# Altium schematic sheet LED_Readout.SchDoc
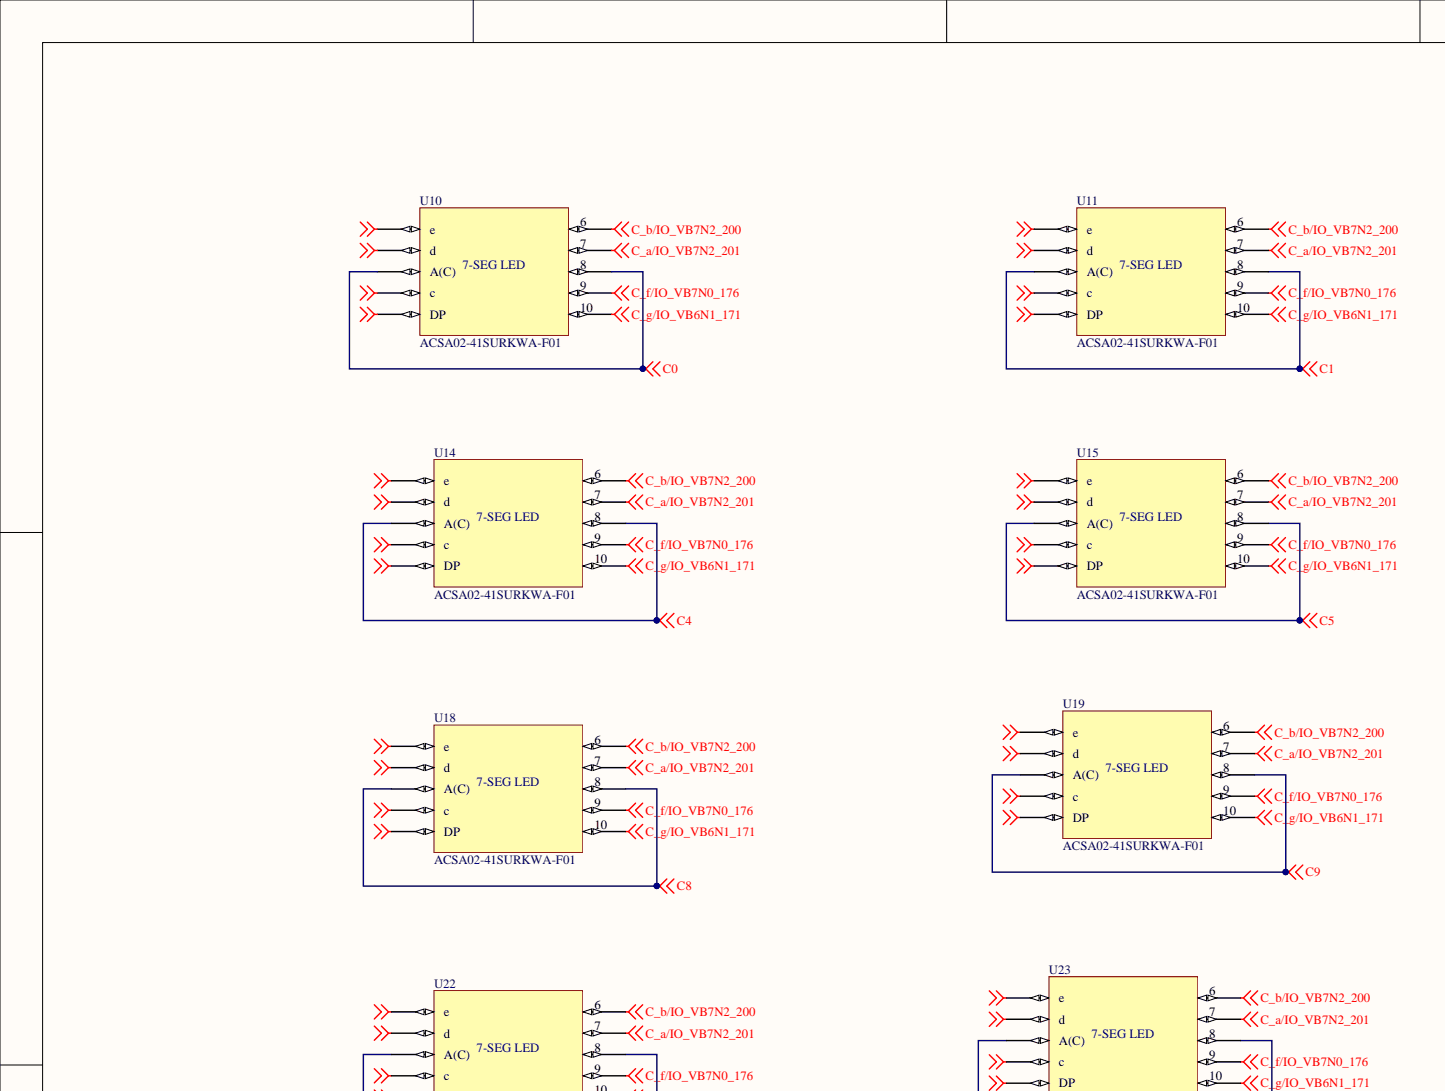
[diagram p0: LED_Readout.SchDoc, top left]
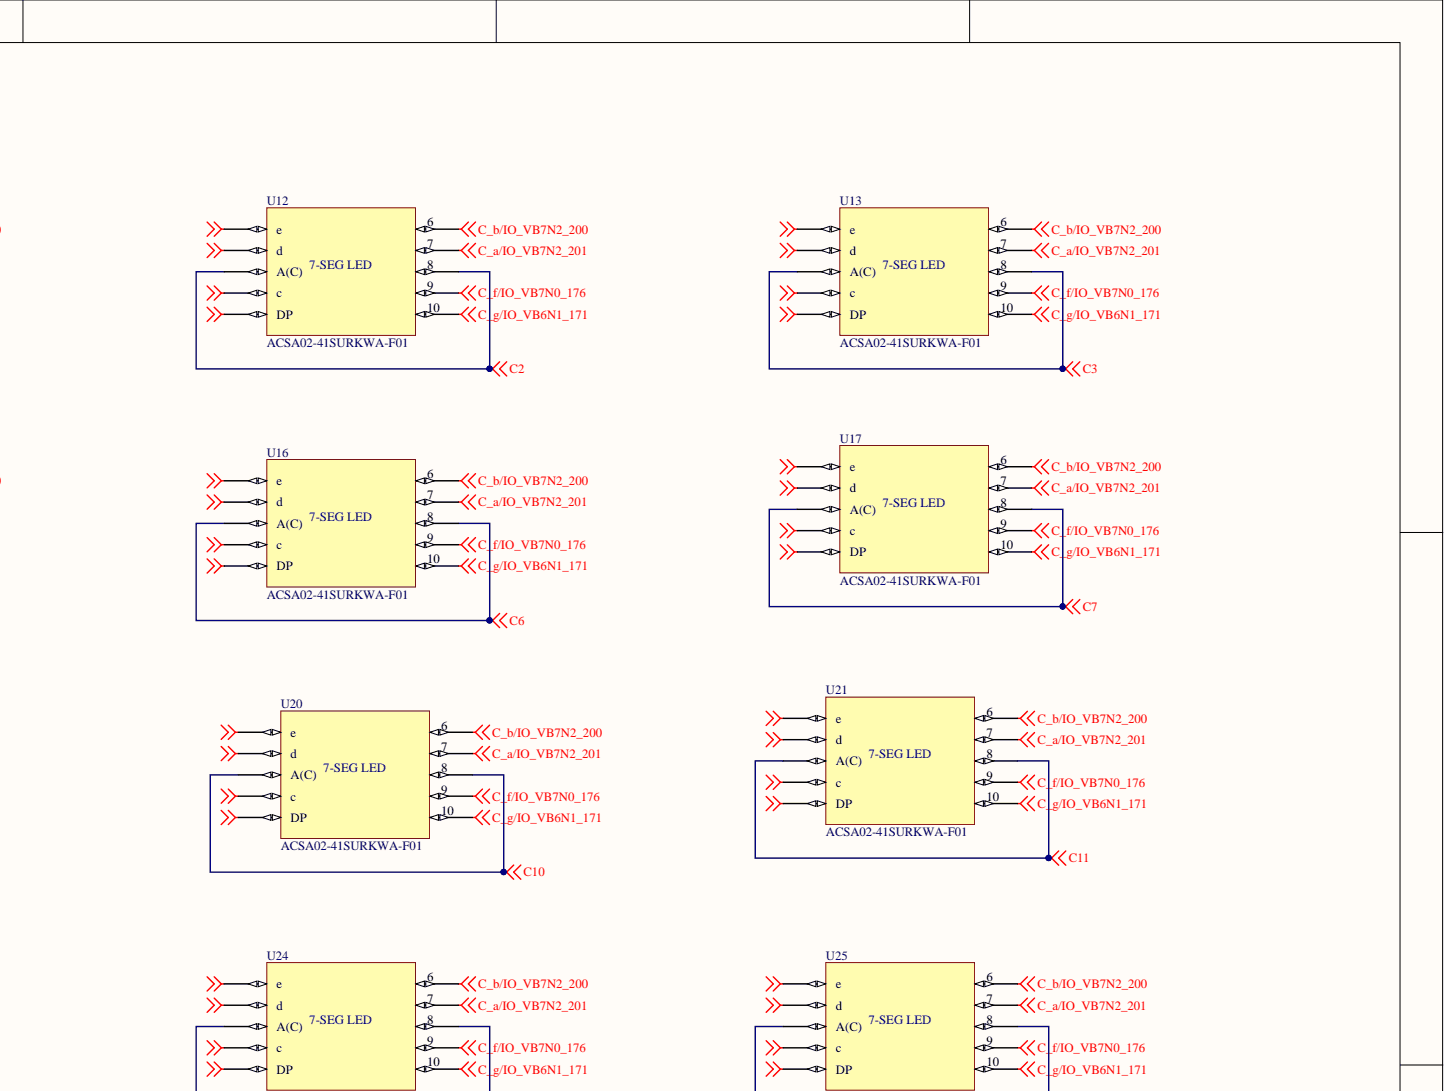
[diagram p1: LED_Readout.SchDoc, top right]
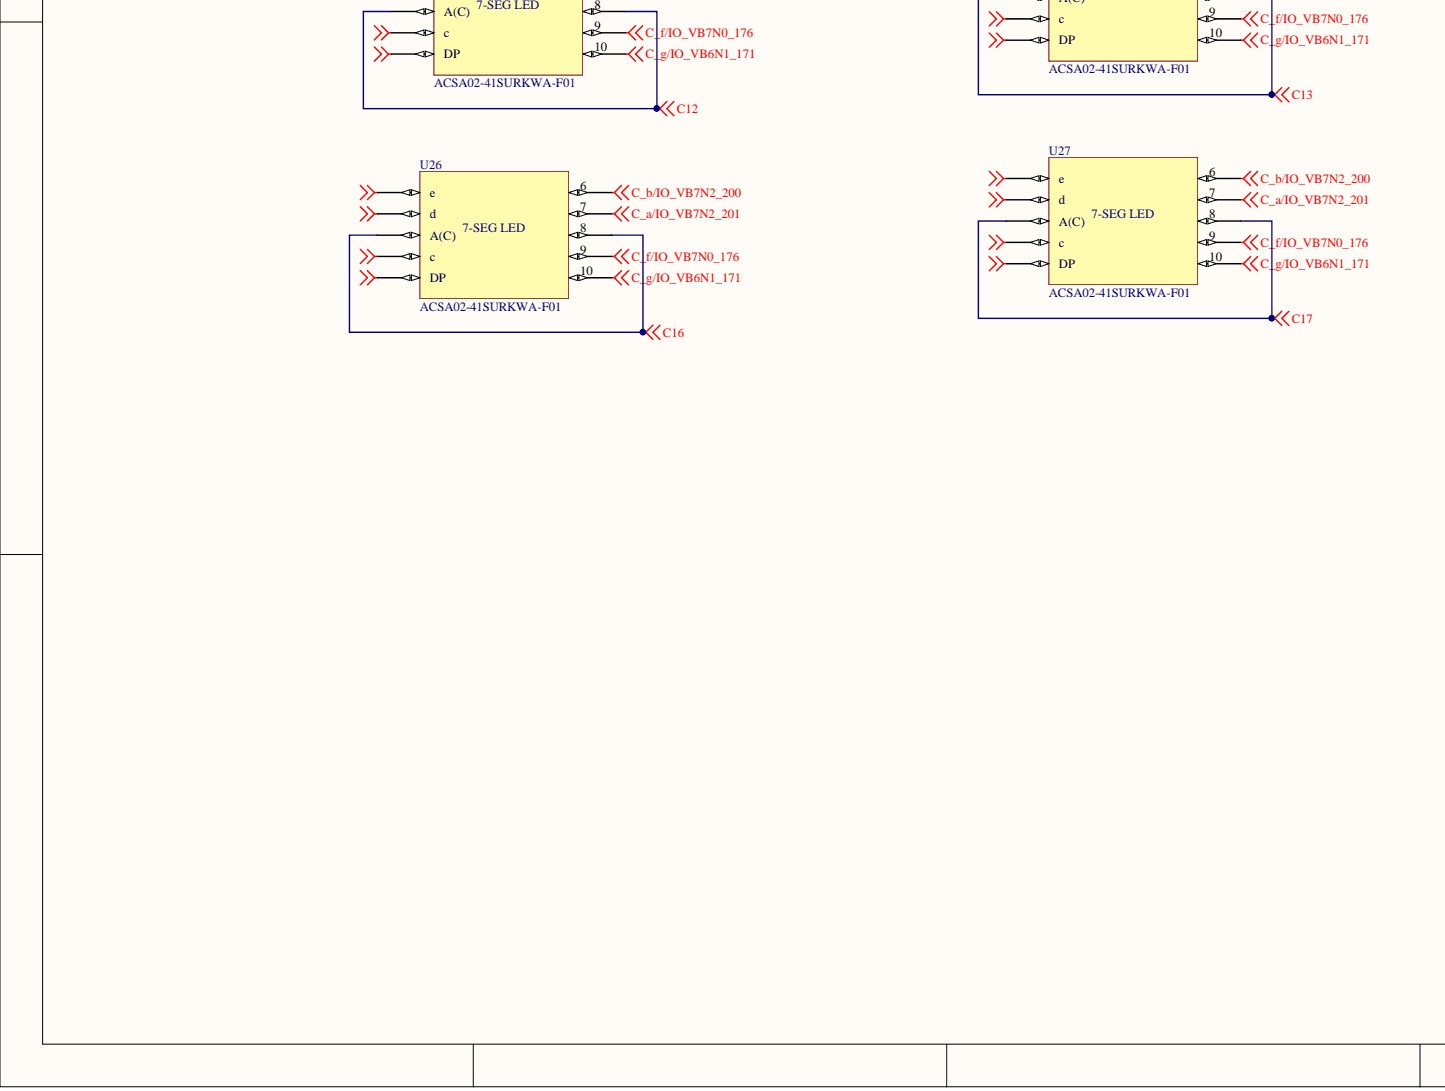
[diagram p2: LED_Readout.SchDoc, bottom left]
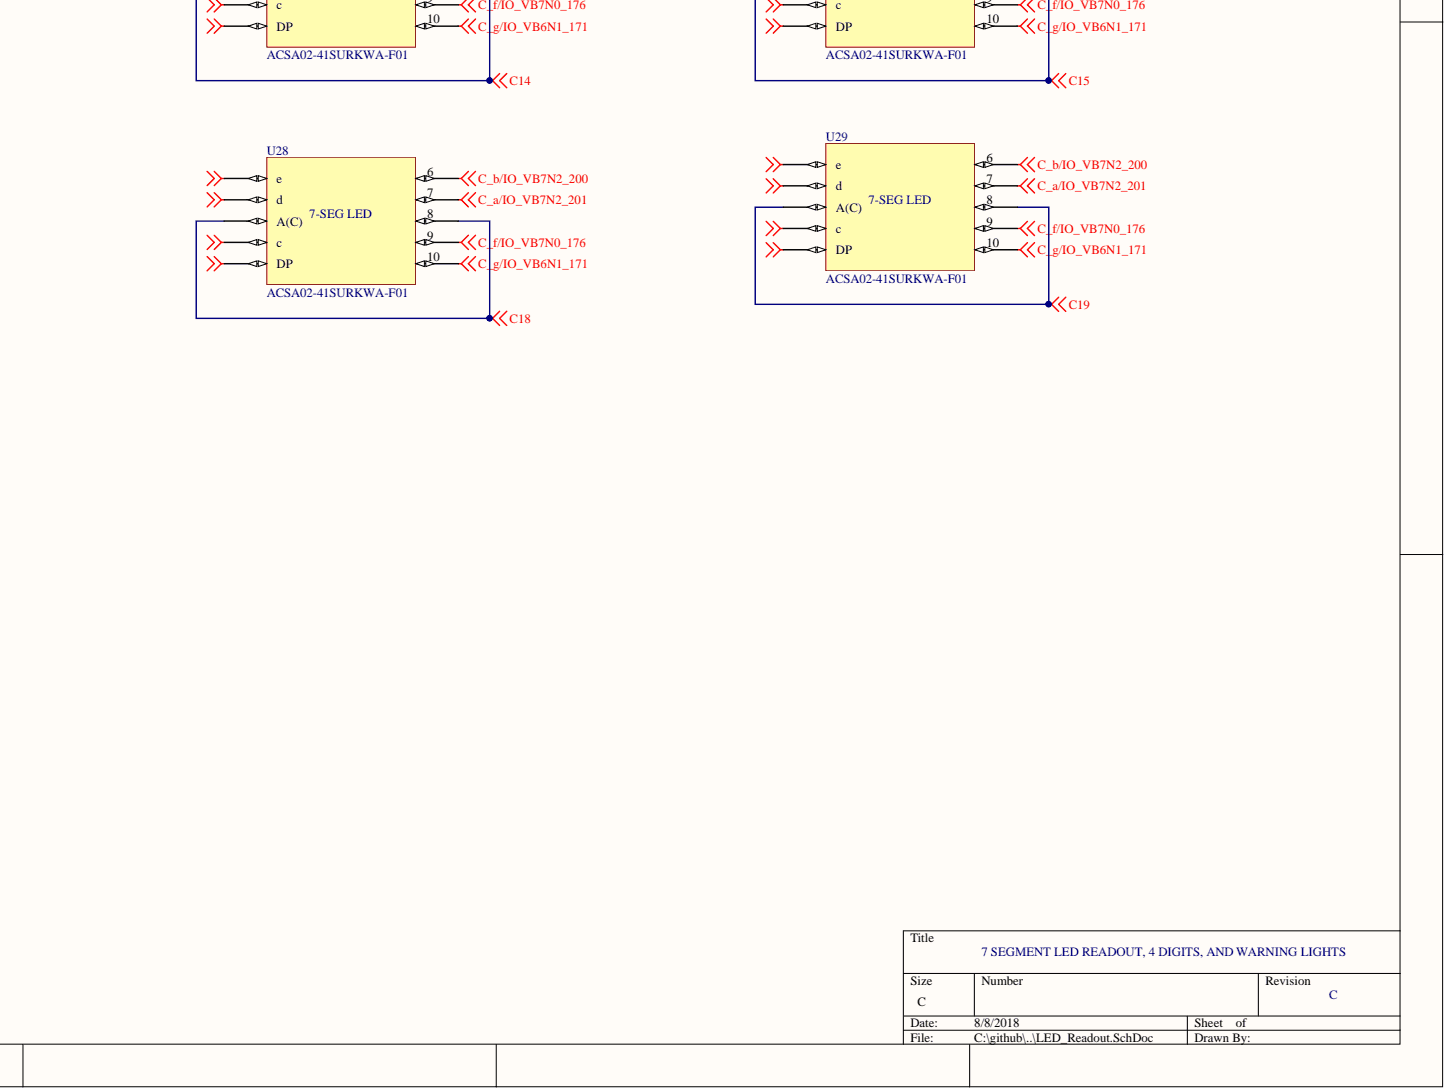
[diagram p3: LED_Readout.SchDoc, bottom right]

## Parts
U10  ACSA02-41SURKWA-F01  [ACSA02-41SURKWA-F01]
U11  ACSA02-41SURKWA-F01  [ACSA02-41SURKWA-F01]
U12  ACSA02-41SURKWA-F01  [ACSA02-41SURKWA-F01]
U13  ACSA02-41SURKWA-F01  [ACSA02-41SURKWA-F01]
U14  ACSA02-41SURKWA-F01  [ACSA02-41SURKWA-F01]
U15  ACSA02-41SURKWA-F01  [ACSA02-41SURKWA-F01]
U16  ACSA02-41SURKWA-F01  [ACSA02-41SURKWA-F01]
U17  ACSA02-41SURKWA-F01  [ACSA02-41SURKWA-F01]
U18  ACSA02-41SURKWA-F01  [ACSA02-41SURKWA-F01]
U19  ACSA02-41SURKWA-F01  [ACSA02-41SURKWA-F01]
U20  ACSA02-41SURKWA-F01  [ACSA02-41SURKWA-F01]
U21  ACSA02-41SURKWA-F01  [ACSA02-41SURKWA-F01]
U22  ACSA02-41SURKWA-F01  [ACSA02-41SURKWA-F01]
U23  ACSA02-41SURKWA-F01  [ACSA02-41SURKWA-F01]
U24  ACSA02-41SURKWA-F01  [ACSA02-41SURKWA-F01]
U25  ACSA02-41SURKWA-F01  [ACSA02-41SURKWA-F01]
U26  ACSA02-41SURKWA-F01  [ACSA02-41SURKWA-F01]
U27  ACSA02-41SURKWA-F01  [ACSA02-41SURKWA-F01]
U28  ACSA02-41SURKWA-F01  [ACSA02-41SURKWA-F01]
U29  ACSA02-41SURKWA-F01  [ACSA02-41SURKWA-F01]

## Nets
C0: U10.3(A(C)) U10.8
C1: U11.3(A(C)) U11.8
C2: U12.3(A(C)) U12.8
C3: U13.3(A(C)) U13.8
C4: U14.3(A(C)) U14.8
C5: U15.3(A(C)) U15.8
C6: U16.3(A(C)) U16.8
C7: U17.3(A(C)) U17.8
C8: U18.3(A(C)) U18.8
C9: U19.3(A(C)) U19.8
C10: U20.3(A(C)) U20.8
C11: U21.3(A(C)) U21.8
C12: U22.3(A(C)) U22.8
C13: U23.3(A(C)) U23.8
C14: U24.3(A(C)) U24.8
C15: U25.3(A(C)) U25.8
C16: U26.3(A(C)) U26.8
C17: U27.3(A(C)) U27.8
C18: U28.3(A(C)) U28.8
C19: U29.3(A(C)) U29.8
C_a/IO_VB7N2_201: U10.7 U11.7 U12.7 U13.7 U14.7 U15.7 U16.7 U17.7 U18.7 U19.7 U20.7 U21.7 U22.7 U23.7 U24.7 U25.7 U26.7 U27.7 U28.7 U29.7
C_b/IO_VB7N2_200: U10.6 U11.6 U12.6 U13.6 U14.6 U15.6 U16.6 U17.6 U18.6 U19.6 U20.6 U21.6 U22.6 U23.6 U24.6 U25.6 U26.6 U27.6 U28.6 U29.6
C_c/IO_VB7N2_197: U10.4(c) U11.4(c) U12.4(c) U13.4(c) U14.4(c) U15.4(c) U16.4(c) U17.4(c) U18.4(c) U19.4(c) U20.4(c) U21.4(c) U22.4(c) U23.4(c) U24.4(c) U25.4(c) U26.4(c) U27.4(c) U28.4(c) U29.4(c)
C_d/IO_VB7N2_196: U10.2(d) U11.2(d) U12.2(d) U13.2(d) U14.2(d) U15.2(d) U16.2(d) U17.2(d) U18.2(d) U19.2(d) U20.2(d) U21.2(d) U22.2(d) U23.2(d) U24.2(d) U25.2(d) U26.2(d) U27.2(d) U28.2(d) U29.2(d)
C_DP/IO_VB7N2_194: U10.5(DP) U11.5(DP) U12.5(DP) U13.5(DP) U14.5(DP) U15.5(DP) U16.5(DP) U17.5(DP) U18.5(DP) U19.5(DP) U20.5(DP) U21.5(DP) U22.5(DP) U23.5(DP) U24.5(DP) U25.5(DP) U26.5(DP) U27.5(DP) U28.5(DP) U29.5(DP)
C_e/IO_VB7N0_183: U10.1(e) U11.1(e) U12.1(e) U13.1(e) U14.1(e) U15.1(e) U16.1(e) U17.1(e) U18.1(e) U19.1(e) U20.1(e) U21.1(e) U22.1(e) U23.1(e) U24.1(e) U25.1(e) U26.1(e) U27.1(e) U28.1(e) U29.1(e)
C_f/IO_VB7N0_176: U10.9 U11.9 U12.9 U13.9 U14.9 U15.9 U16.9 U17.9 U18.9 U19.9 U20.9 U21.9 U22.9 U23.9 U24.9 U25.9 U26.9 U27.9 U28.9 U29.9
C_g/IO_VB6N1_171: U10.10 U11.10 U12.10 U13.10 U14.10 U15.10 U16.10 U17.10 U18.10 U19.10 U20.10 U21.10 U22.10 U23.10 U24.10 U25.10 U26.10 U27.10 U28.10 U29.10

## Library data of the parts
U10-U29 = ACSA02-41SURKWA-F01: Surface Mount Display; Component Kind: Standard; Library Name: schematics.SchLib; Supplier 1: Digi-Key
